# Altium schematic sheet IMU.SchDoc(IMU)
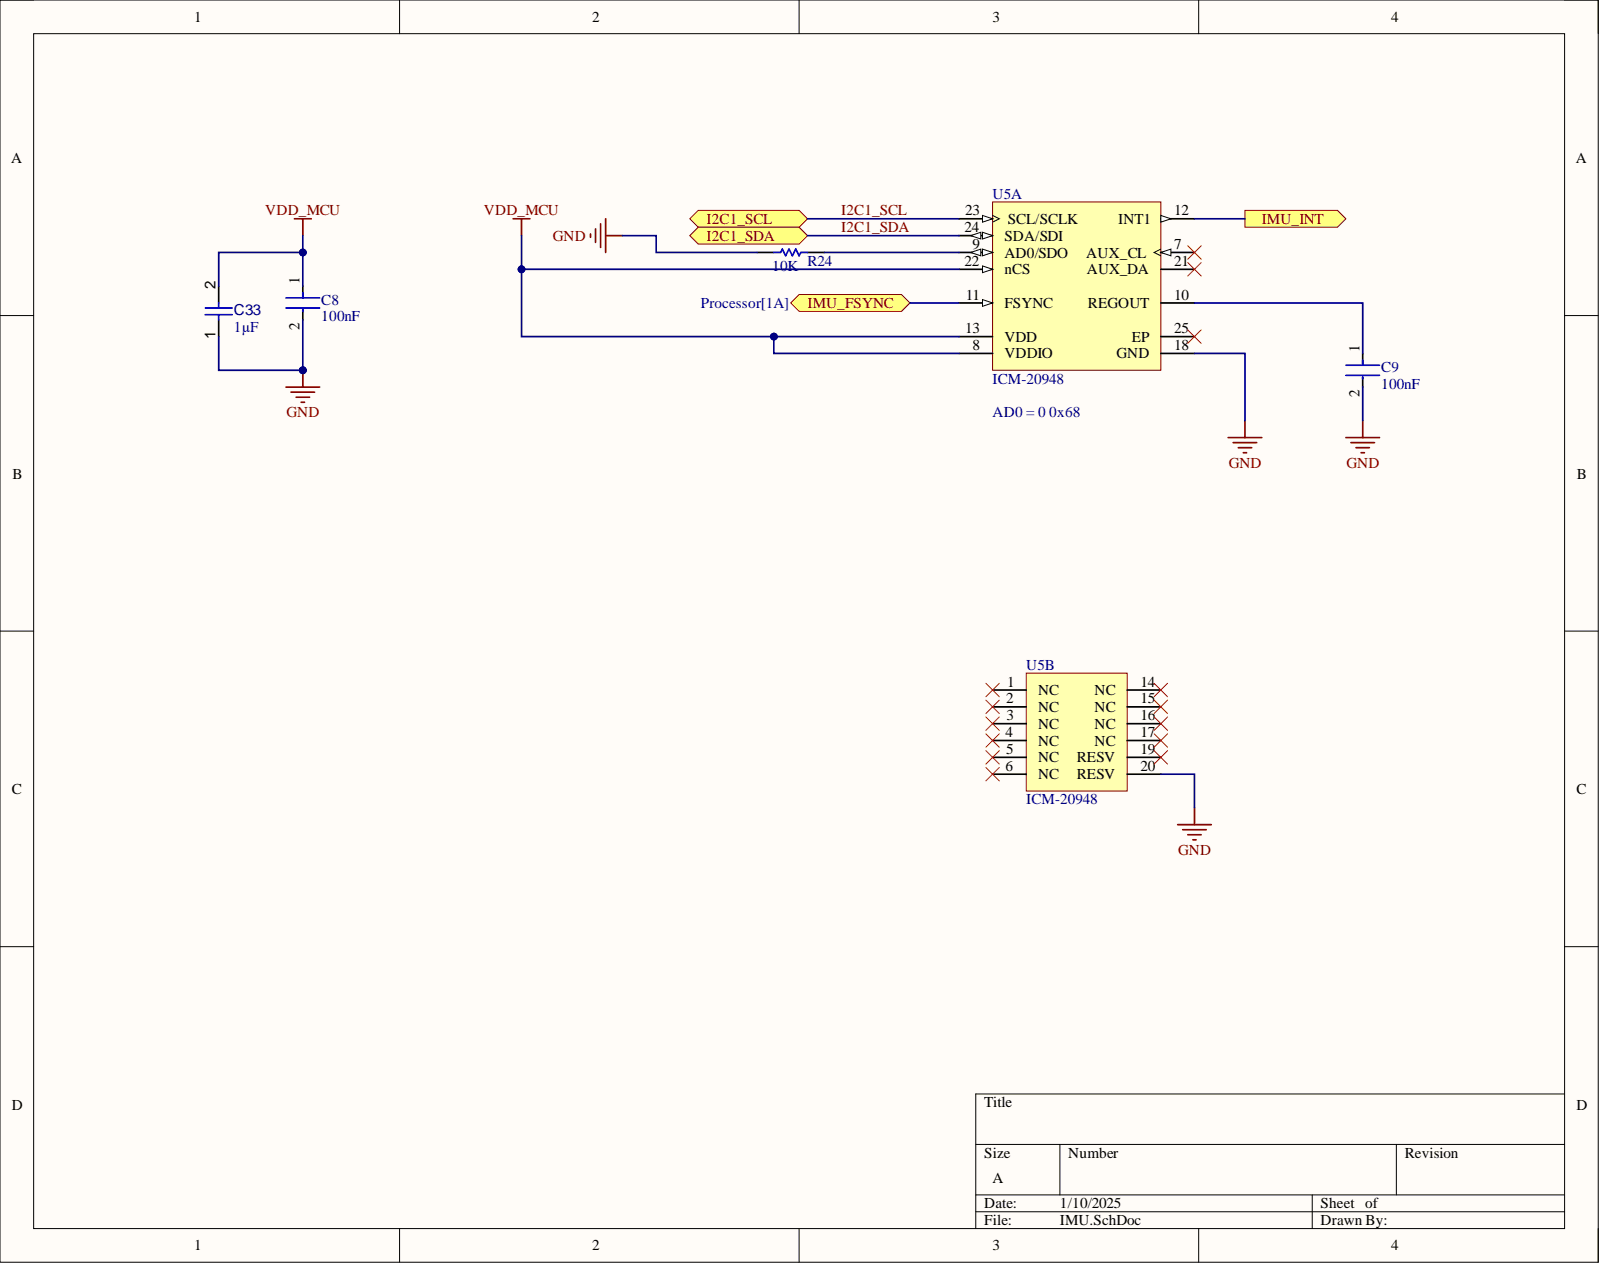
[diagram p0: IMU.SchDoc]

## Parts
C8  100nF
C9  100nF
C33  value 1µF  (hidden comment: Capacitor 1 uF +/- 10% 6.3 V 0402)
R24  10K
U5  ICM-20948  (parts A,B)

## Ports
I2C1_SCL, I2C1_SDA, IMU_FSYNC, IMU_INT

## Nets
GND: C8.2 C9.2 C33.1 R24.2 U5.18(GND) U5.20(RESV)
VDD_MCU: C8.1 C33.2 U5.8(VDDIO) U5.13(VDD) U5.22(nCS)
I2C1_SCL: U5.23(SCL/SCLK) ; port I2C1_SCL
I2C1_SDA: U5.24(SDA/SDI) ; port I2C1_SDA
IMU_FSYNC: U5.11(FSYNC) ; port IMU_FSYNC
IMU_INT: U5.12(INT1) ; port IMU_INT
NetC9_1: C9.1 U5.10(REGOUT)
NetR24_1: R24.1 U5.9(AD0/SDO)

Not connected: U5 1(NC) 2(NC) 3(NC) 4(NC) 5(NC) 6(NC) 7(AUX_CL) 14(NC) 15(NC) 16(NC) 17(NC) 19(RESV) 21(AUX_DA) 25(EP)

## Library data of the parts
C8 C9 = CMP-2008-04639-2: Chip Multilayer Ceramic Capacitors for General Purpose, 0402, 0.10uF, X7R, 15%, 10%, 50V; Dissipation Factor: 0.025%; Capacitance: 100nF; Case Code (Imperial): 0402; Case Code (Metric): 1005; Case/Package: 0402; Component Kind: Standard; Depth: 0.5mm; Dielectric: X7R; Dielectric Material: Ceramic; Height: 0.5mm; Lead Free: Lead Free; Length: 1mm; Library Name: Altium Content Vault; Material: Ceramic; Max Operating Temperature: 125°C; Min Operating Temperature: -55°C; Mount: Surface Mount; Package Quantity: 10000; Packaging: Tape and Reel; REACH SVHC: No SVHC; Resistor Type: Ceramic; Termination: SMD/SMT; Thickness: 0.022inch; Tolerance: 10%; Voltage: 50V; Voltage Rating: 50V; Voltage Rating (DC): 50V; Weight: 0.000212oz; Width: 0.5mm
C33 = CMP-001-00008-6: Chip Capacitor, 1 uF, +/- 10%, 6.3 V, 0402 (1005 Metric); Capacitor Type: Ceramic; Voltage Rating: 6.3V; Case/Package: 0402; Component Kind: Standard; Max Operating Temperature: 85°C; Min Operating Temperature: -55°C; Mounting Technology: SM; Pins: 2; RoHS Compliant: True; Tolerance: 10%; Library Name: Openwater
R24 = CMP-2002-07353-1: Manufacturer: Yageo / Phycomp; Part Number: RC0402FR-0710KL; Component Kind: Standard; Library Name: Altium Content Vault
U5 = CMP-2000-07532-1: World’s Lowest Power 9-Axis MEMS MotionTracking(TM) Device, 1.71 to 3.6 V, -40 to 85 degC, 24-Pin QFN, RoHS, Tape and Reel; Manufacturer: TDK; Component Kind: Standard; Datasheet URL: https://www.invensense.com/wp-content/uploads/2016/06/DS-000189-ICM-20948-v1.3.pdf; Datasheet Version: Rev. 1.3, 06/2017; Library Name: Altium Content Vault; Manufacturer URL: http://www.tdk.com/; Mounting Technology: SurfaceMount; Package Description: 24-Pin Quad Flat No-lead Package, Body 3 x 3 mm, Pitch 0.4 mm; Package Reference: QFN-24
